# Altium schematic sheet Controller list.SchDoc
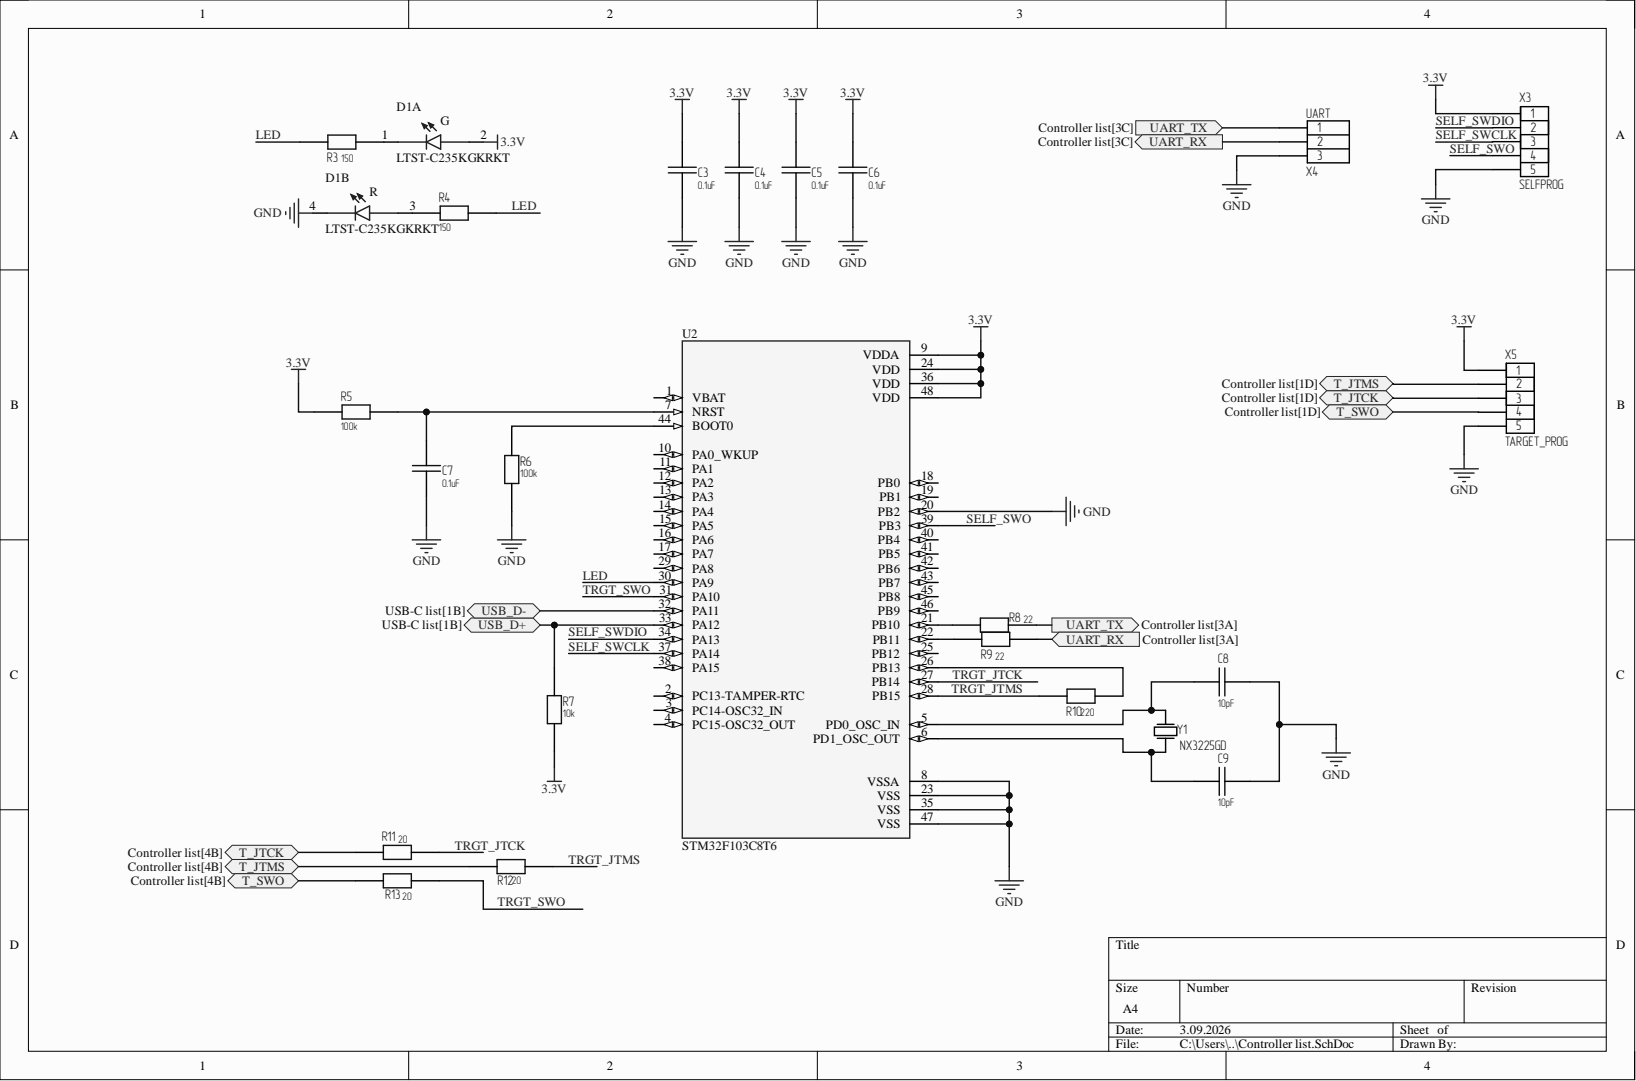
[diagram p0: Controller list.SchDoc]

## Parts
C3  0.1uF  [C_0603]
C4  0.1uF  [C_0603]
C5  0.1uF  [C_0603]
C6  0.1uF  [C_0603]
C7  0.1uF  [C_0603]
C8  10pF  [C_0603]
C9  10pF  [C_0603]
D1  LTST-C235KGKRKT  [LED_LTST-C235KGKRKT]  (parts A,B)
R3  150  [R_0603]
R4  150  [R_0603]
R5  100k  [R_0603]
R6  100k  [R_0603]
R7  10k  [R_0603]
R8  22  [R_0603]
R9  22  [R_0603]
R10  220  [R_0603]
R11  20  [R_0603]
R12  20  [R_0603]
R13  20  [R_0603]
U2  STM32F103C8T6  [QFP50P900X900X160-48N]
X3  SELFPROG  [PLS-5-2]
X4  UART  [PLS-3-2]
X5  TARGET_PROG  [PLS-5-2]
Y1  NX3225GD  [NX3225GD]

## Ports
T_JTCK, T_JTMS, T_SWO, UART_RX, UART_TX, USB_D+, USB_D-

## Nets
3.3V: C3.2 C4.2 C5.2 C6.2 D1.2 R5.2 R7.2 U2.9(VDDA) U2.24(VDD) U2.36(VDD) U2.48(VDD) X3.1 X5.1
GND: C3.1 C4.1 C5.1 C6.1 C7.1 C8.1 C9.1 D1.4 R6.1 U2.8(VSSA) U2.20(PB2) U2.23(VSS) U2.35(VSS) U2.47(VSS) X3.5 X4.3 X5.5
LED: R3.1 R4.1 U2.30(PA9)
SELF_SWCLK: U2.37(PA14) X3.3
SELF_SWDIO: U2.34(PA13) X3.2
SELF_SWO: U2.39(PB3) X3.4
T_JTCK: R11.2 X5.3 ; port T_JTCK
T_JTMS: R12.2 X5.2 ; port T_JTMS
T_SWO: R13.2 X5.4 ; port T_SWO
TRGT_JTCK: R11.1 U2.27(PB14)
TRGT_JTMS: R10.1 R12.1 U2.28(PB15)
TRGT_SWO: R13.1 U2.31(PA10)
UART_RX: R9.2 X4.2 ; port UART_RX
UART_TX: R8.2 X4.1 ; port UART_TX
NetC7_2: C7.2 R5.1 U2.7(NRST)
NetC8_2: C8.2 U2.5(PD0_OSC_IN) Y1.2
NetC9_2: C9.2 U2.6(PD1_OSC_OUT) Y1.1
NetD1_1: D1.1 R3.2
NetD1_3: D1.3 R4.2
NetR6_2: R6.2 U2.44(BOOT0)
NetR7_1: R7.1 U2.33(PA12)
NetR8_1: R8.1 U2.21(PB10)
NetR9_1: R9.1 U2.22(PB11)
NetR10_2: R10.2 U2.26(PB13)
NetU2_32: U2.32(PA11)

Not connected: U2 1(VBAT) 2(PC13-TAMPER-RTC) 3(PC14-OSC32_IN) 4(PC15-OSC32_OUT) 10(PA0_WKUP) 11(PA1) 12(PA2) 13(PA3) 14(PA4) 15(PA5) 16(PA6) 17(PA7) 18(PB0) 19(PB1) 25(PB12) 29(PA8) 38(PA15) 40(PB4) 41(PB5) 42(PB6) 43(PB7) 45(PB8) 46(PB9)

## Library data of the parts
C3-C7 = C0603: Capacitor; Part number: CC0603ZRY5V9BB104; Component Kind: Standard; Library Name: capacitors.schlib; Value: 0.1uF
C8 C9 = C0603: Capacitor; Part number: CC0603CRNPO9BN100; Component Kind: Standard; Library Name: capacitors.schlib; Value: 10pF
D1 = LTST-C235KGKRKT: Green, Red 571nm Green, 631nm Red LED Indication - Discrete 2V Green, 2V Red 1206 (3216 Metric); MF: Lite-On Inc.; Check_prices: https://www.snapeda.com/parts/LTST-C235KGKRKT/Lite-On/view-part/?ref=eda; Component Kind: Standard; Library Name: LTST-C235KGKRKT.SchLib; MP: LTST-C235KGKRKT; Package: 1206 Lite-On; Part Number: LTST-C235KGKRKT; SnapEDA_Link: https://www.snapeda.com/parts/LTST-C235KGKRKT/Lite-On/view-part/?ref=snap
R3 = R0603: Resistor; Parameter 1: RC0603FR-07150RL; Component Kind: Standard; Library Name: resistors.schlib; Value: 150
R4 = R0603: Resistor; Part Number: RC0603FR-07150RL; Component Kind: Standard; Library Name: resistors.schlib; Value: 150
R5 R6 = R0603: Resistor; Part number: RT0603DRD07100KL; Component Kind: Standard; Library Name: resistors.schlib; Value: 100k
R7 = R0603: Resistor; Part number: RC0603JR-0710KL; Component Kind: Standard; Library Name: resistors.schlib; Value: 10k
R8 R9 = R0603: Resistor; Part number: RC0603FR-0722RL; Component Kind: Standard; Library Name: resistors.schlib; Value: 22
R10 = R0603: Resistor; Part number: RC0603FR-07220RL; Component Kind: Standard; Library Name: resistors.schlib; Value: 220
R11-R13 = R0603: Resistor; Part number: RC0603FR-0720RL; Component Kind: Standard; Library Name: resistors.schlib; Value: 20
U2 = STM32F103C8T6: ARM® Cortex®-M3 STM32F1 Microcontroller IC 32-Bit 72MHz 64KB (64K x 8) FLASH 48-LQFP (7x7); MF: STMicroelectronics; Check_prices: https://www.snapeda.com/parts/STM32F103C8T6/STMicroelectronics/view-part/?ref=eda; Component Kind: Standard; Library Name: STM32F103C8T6.SchLib; MP: STM32F103C8T6; Package: LQFP-48 STMicroelectronics; Part number: STM32F103C8T6; SnapEDA_Link: https://www.snapeda.com/parts/STM32F103C8T6/STMicroelectronics/view-part/?ref=snap
X3 X5 = PLS2-5: Header, 5-Pin; Part number: KLS1-207-1-05-S; Component Kind: Standard; LatestRevisionDate: 17-Jul-2002; LatestRevisionNote: Re-released for DXP Platform.; Library Name: connectors_socets.schlib; Publisher: Altium Limited
X4 = PLS2-3: Header, 3-Pin; Part number: C2100-03ASGAS0R; Component Kind: Standard; LatestRevisionDate: 17-Jul-2002; LatestRevisionNote: Re-released for DXP Platform.; Parameter 1: UART; Library Name: connectors_socets.schlib; Publisher: Altium Limited
Y1 = NX3225GD: Crystal Oscillator; Part number: NX3225GD-8MHZ-STD-CRA-3; Component Kind: Standard; Library Name: crystals_oscillators.schlib
